# Altium schematic sheet 万年历.SchDoc
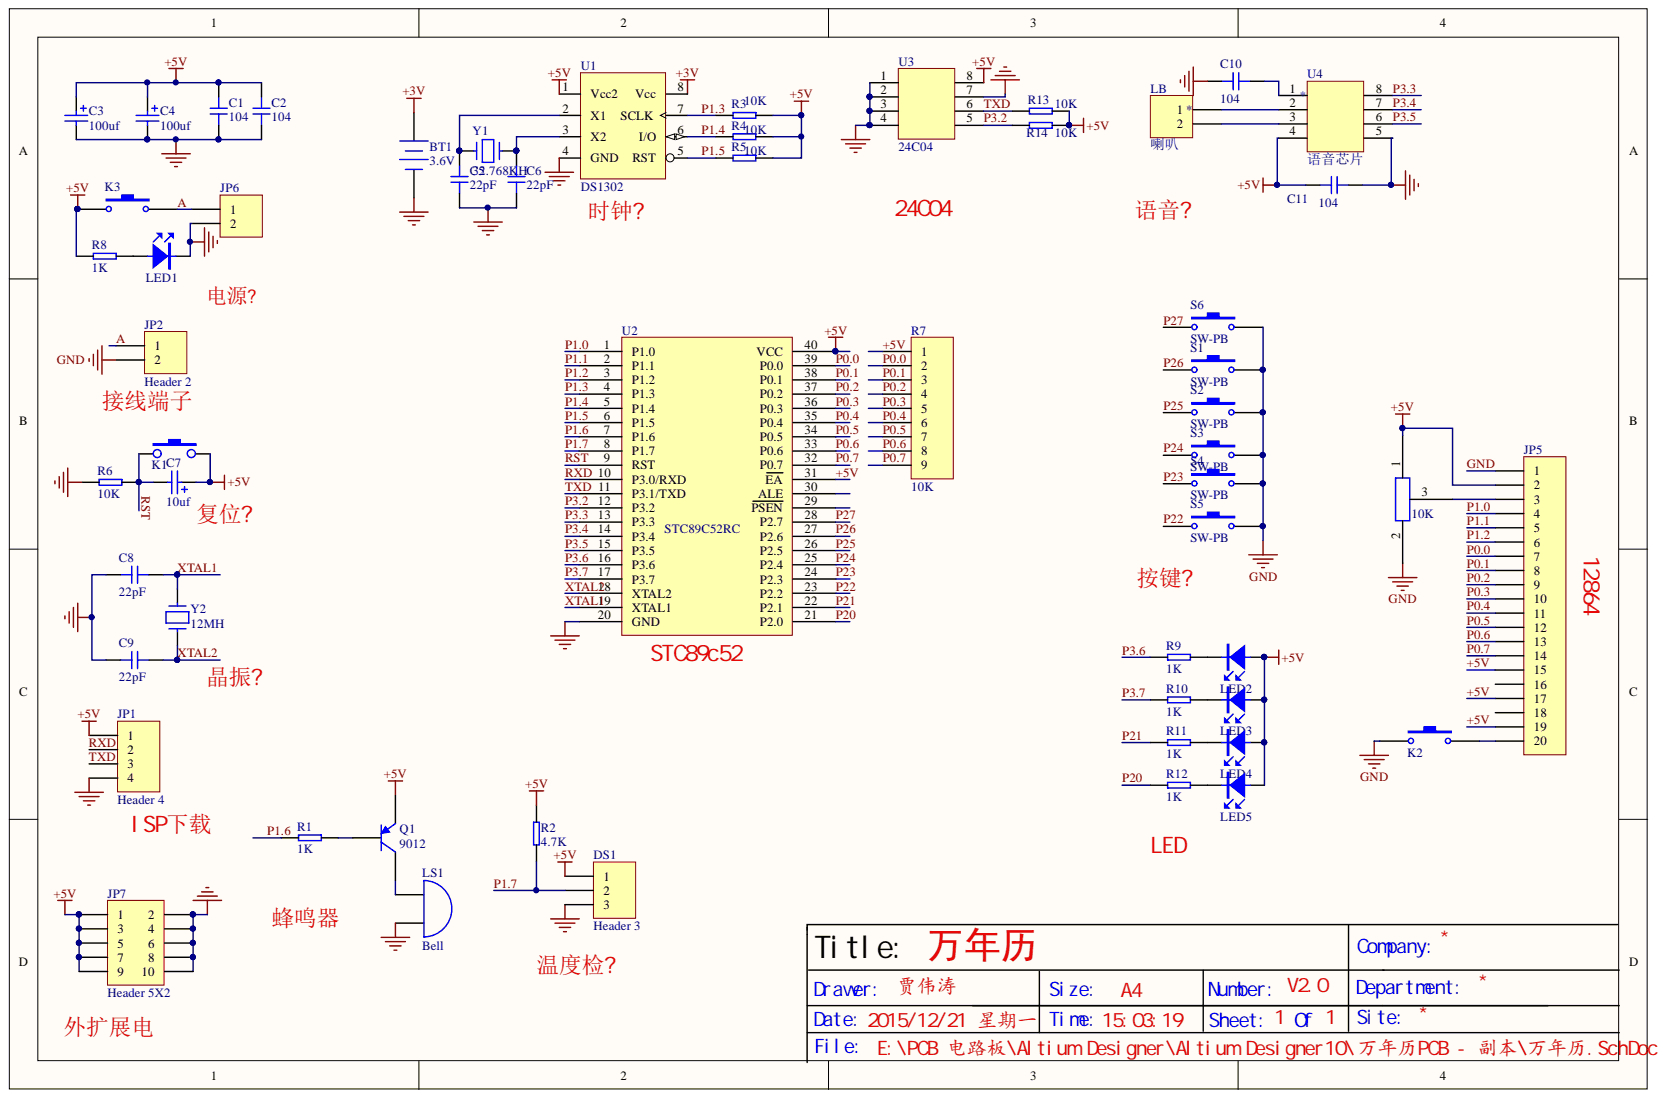
[diagram p0: 万年历.SchDoc]

## Parts
BT1  3.6V  [CR2032]
C1  104  [RAD0.1]
C2  104  [RAD0.1]
C3  100uf  [RB.1/.2]
C4  100uf  [RB.1/.2]
C5  22pF  [RAD0.1]
C6  22pF  [RAD0.1]
C7  10uf  [RB.1/.2]
C8  22pF  [RAD0.1]
C9  22pF  [RAD0.1]
C10  104  [RAD0.1]
C11  104  [RAD0.1]
DS1  Header 3  [HDR1X3]
JP1  Header 4  [HDR1X4]
JP2  Header 2  [DC-3.5mm]
JP5  Header 20  [HDR1X20]  (value not drawn)
JP6  Header 2  [接线端子]  (value not drawn)
JP7  Header 5X2  [HDR2X5]
K1  KEY  [KEY-4*6*6]  (value not drawn)
K2  SW-PB  [dpdt-6]  (value not drawn)
K3  SW-PB  [dpdt-6]  (value not drawn)
LB  Comme: 喇叭  [HDR1X2]
LED1  LED  [0805LD-红]
LED2  LED  [0805LD-红]
LED3  LED  [0805LD-绿]
LED4  LED  [0805LD - 蓝]
LED5  LED  [0805LD-黄]
LS1  Bell  [BELL]
Q1  9012  [9012]
R1  1K  [0805]
R2  4.7K  [0805]
R3  10K  [0805]
R4  10K  [0805]
R5  10K  [0805]  (value not drawn)
R6  10K  [0805]
R7  10K  [RES-9]
R8  1K  [0805]
R9  1K  [0805]
R10  1K  [0805]
R11  1K  [0805]
R12  1K  [0805]
R13  10K  [0805]
R14  10K  [0805]
RE1  10K  [VR]
S1  SW-PB  [KEY-4]
S2  SW-PB  [KEY-4]
S3  SW-PB  [KEY-4]
S4  SW-PB  [KEY-4]
S5  SW-PB  [KEY-4]
S6  SW-PB  [KEY-4]
U1  DS1302  [DIP8]
U2  STC89C52RC  [DIP-40]
U3  24C04  [DIP8]
U4  (no value)  [DIP8]
Y1  32.768KH  [32.768kh]
Y2  12MH  [XTAL]

## Nets
+3V: BT1.1 U1.8(Vcc)
+5V: C1.2 C2.2 C3.1 C4.1 C7.1 C11.2 DS1.1 JP1.1 JP5.2 JP5.15 JP5.17 JP5.19 JP7.1 JP7.3 JP7.5 JP7.7 JP7.9 K1.2 K3.1 LED2.A LED3.A LED4.A LED5.A Q1.3 R2.2 R3.2 R4.2 R5.2 R7.1 R8.2 R13.2 R14.2 RE1.1 U1.1(Vcc2) U2.31(EA) U2.40(VCC) U3.8 U4.4
A: JP2.1 JP6.1 K3.2
GND: BT1.2 C1.1 C2.1 C3.2 C4.2 C5.1 C6.1 C8.1 C9.1 C10.2 C11.1 DS1.3 JP1.4 JP2.2 JP5.1 JP6.2 JP7.2 JP7.4 JP7.6 JP7.8 JP7.10 K2.2 LED1.K LS1.2 R6.1 RE1.2 S1.2 S2.2 S3.2 S4.2 S5.2 S6.2 U1.4(GND) U2.20(GND) U3.1 U3.2 U3.3 U3.4 U3.7 U4.5
P0.0: JP5.7 R7.2 U2.39(P0.0)
P0.1: JP5.8 R7.3 U2.38(P0.1)
P0.2: JP5.9 R7.4 U2.37(P0.2)
P0.3: JP5.10 R7.5 U2.36(P0.3)
P0.4: JP5.11 R7.6 U2.35(P0.4)
P0.5: JP5.12 R7.7 U2.34(P0.5)
P0.6: JP5.13 R7.8 U2.33(P0.6)
P0.7: JP5.14 R7.9 U2.32(P0.7)
P1.0: JP5.4 U2.1(P1.0)
P1.1: JP5.5 U2.2(P1.1)
P1.2: JP5.6 U2.3(P1.2)
P1.3: R3.1 U1.7(SCLK) U2.4(P1.3)
P1.4: R4.1 U1.6(I/O) U2.5(P1.4)
P1.5: R5.1 U1.5(RST) U2.6(P1.5)
P1.6: R1.1 U2.7(P1.6)
P1.7: DS1.2 R2.1 U2.8(P1.7)
P3.2: R14.1 U2.12(P3.2) U3.5
P3.3: U2.13(P3.3) U4.8
P3.4: U2.14(P3.4) U4.7
P3.5: U2.15(P3.5) U4.6
P3.6: R9.2 U2.16(P3.6)
P3.7: R10.2 U2.17(P3.7)
P20: R12.2 U2.21(P2.0)
P21: R11.2 U2.22(P2.1)
P22: S5.1 U2.23(P2.2)
P23: S4.1 U2.24(P2.3)
P24: S3.1 U2.25(P2.4)
P25: S2.1 U2.26(P2.5)
P26: S1.1 U2.27(P2.6)
P27: S6.1 U2.28(P2.7)
RST: C7.2 K1.1 R6.2 U2.9(RST)
RXD: JP1.2 U2.10(P3.0/RXD)
TXD: JP1.3 R13.1 U2.11(P3.1/TXD) U3.6
XTAL1: C8.2 U2.19(XTAL1) Y2.1
XTAL2: C9.2 U2.18(XTAL2) Y2.2
NetC5_2: C5.2 U1.2(X1) Y1.2
NetC6_2: C6.2 U1.3(X2) Y1.1
NetC10_1: C10.1 U4.1
NetJP5_3: JP5.3 RE1.3
NetJP5_20: JP5.20 K2.1
NetLB_1: LB.1 U4.2
NetLB_2: LB.2 U4.3
NetLED1_A: LED1.A R8.1
NetLED2_K: LED2.K R9.1
NetLED3_K: LED3.K R10.1
NetLED4_K: LED4.K R11.1
NetLED5_K: LED5.K R12.1
NetLS1_1: LS1.1 Q1.1
NetQ1_2: Q1.2 R1.2

Not connected: JP5 16 18; U2 29(PSEN) 30(ALE)

## Library data of the parts
BT1 = B: Multicell Battery; Component Kind: Standard; LatestRevisionDate: 17-Jul-2002; LatestRevisionNote: Re-released for DXP Platform.; PackageDescription: Battery; 2 Leads; PackageReference: BAT-2; Published: 24-Mar-1999; Library Name: 智能大棚.IntLib; Publisher: Altium Limited
C1 C2 C5 C6 C8-C11 = CAP: Component Kind: Standard
  C8 C9: Library Name: Mini51Lib.SchLib
  C1 C2 C5 C6 C10 C11: Library Name: 智能大棚.IntLib
C3 C4 = Ca: Polarized Capacitor (Axial); Value: 10uf; Component Kind: Standard; LatestRevisionDate: 17-Jul-2002; LatestRevisionNote: Re-released for DXP Platform.; PackageDescription: Polarized Capacitor; 2 Leads; PackageReference: POLAR0.8; Published: 8-Jun-2000; Signal Integrity: Cap Pol; Simulation: CAP; Library Name: 智能大棚.IntLib; Publisher: Altium Limited
C7 = Cap Pol2: Polarized Capacitor (Axial); Component Kind: Standard; LatestRevisionDate: 17-Jul-2002; LatestRevisionNote: Re-released for DXP Platform.; Library Name: Miscellaneous Devices.IntLib; PackageDescription: Polarized Capacitor; 2 Leads; PackageReference: POLAR0.8; Published: 8-Jun-2000; Signal Integrity: Cap Pol; Simulation: CAP; Value: 10uf; Publisher: Altium Limited
DS1 = Header 3: Header, 3-Pin; Component Kind: Standard; LatestRevisionDate: 17-Jul-2002; LatestRevisionNote: Re-released for DXP Platform.; Library Name: Miscellaneous Connectors.IntLib; Signal Integrity: Connector; Publisher: Altium Limited
JP1 = Header 4: Header, 4-Pin; Component Kind: Standard; LatestRevisionDate: 17-Jul-2002; LatestRevisionNote: Re-released for DXP Platform.; Library Name: Miscellaneous Connectors.IntLib; Signal Integrity: Connector; Publisher: Altium Limited
JP2 JP6 LB = Header 2: Header, 2-Pin; Component Kind: Standard; LatestRevisionDate: 17-Jul-2002; LatestRevisionNote: Re-released for DXP Platform.; Library Name: Miscellaneous Connectors.IntLib; Signal Integrity: Connector; Publisher: Altium Limited
JP5 = Hea: Header, 20-Pin; Component Kind: Standard; LatestRevisionDate: 17-Jul-2002; LatestRevisionNote: Re-released for DXP Platform.; Signal Integrity: Connector; Library Name: 智能大棚.IntLib; Publisher: Altium Limited
JP7 = Header 5X2: Header, 5-Pin, Dual row; Component Kind: Standard; LatestRevisionDate: 17-Jul-2002; LatestRevisionNote: Re-released for DXP Platform.; Library Name: Miscellaneous Connectors.IntLib; Signal Integrity: Connector; Publisher: Altium Limited
K1 = KEY: push-button; Component Kind: Standard; Library Name: Mini51Lib.SchLib
K2 K3 S1-S6 = SW-PB: Switch; Component Kind: Standard; LatestRevisionDate: 17-Jul-2002; LatestRevisionNote: Re-released for DXP Platform.; Library Name: Miscellaneous Devices.IntLib; Published: 24-Mar-1999; Publisher: Altium Limited
  S1-S6: PackageDescription: Switch; 2 Leads; PackageReference: SPST-2
LED1-LED5 = LED: Light-Emitting Diode; Component Kind: Standard; Library Name: Mini51Lib.SchLib
LS1 = Bell: Electrical Bell; Component Kind: Standard; LatestRevisionDate: 17-Jul-2002; LatestRevisionNote: Re-released for DXP Platform.; Library Name: Miscellaneous Devices.IntLib; PackageReference: PIN2; Published: 24-Mar-1999; Publisher: Altium Limited
Q1 = PNP: PNP Bipolar Transistor; Component Kind: Standard; LatestRevisionDate: 29-May-2009; LatestRevisionNote: IPC-7351 Footprint Added.; Library Name: Miscellaneous Devices.IntLib; PackageDescription: 3-Pin SOT-23 Package 0.95 mm Pitch, 2.4 mm Lead Span; PackageReference: SOT-23B; PackageVersion: Aug-1999; Published: 8-Jun-2000; Signal Integrity: PNP; Simulation: PNP; Publisher: Altium Limited
R1-R6 R8-R14 = RES: Component Kind: Standard
  R6 R8-R12: Library Name: Mini51Lib.SchLib
  R1-R5: Library Name: 智能大棚.IntLib
  R13 R14: Library Name: 万年历.IntLib
R7 = Header 9: Header, 9-Pin; Component Kind: Standard; LatestRevisionDate: 17-Jul-2002; LatestRevisionNote: Re-released for DXP Platform.; Library Name: Miscellaneous Connectors.IntLib; Signal Integrity: Connector; Publisher: Altium Limited
RE1 = RES-: Component Kind: Standard; Library Name: 万年历.SchLib
U2 = STC89C52: Component Kind: Standard; Library Name: Mini51Lib.SchLib
U3 U4 = 24C: Component Kind: Standard; Library Name: 万年历.SchLib
  U4: : 语音芯片
Y1 = C: Crystal; Component Kind: Standard; Library Name: 智能大棚.IntLib
Y2 = CRYSTAL: Component Kind: Standard; Description: Crystal; Library Name: Mini51Lib.SchLib
U1 = DS1302: Component Kind: Standard; Library Name: 单片机及相关.SCHLIB
